# Altium schematic sheet SPI.SchDoc
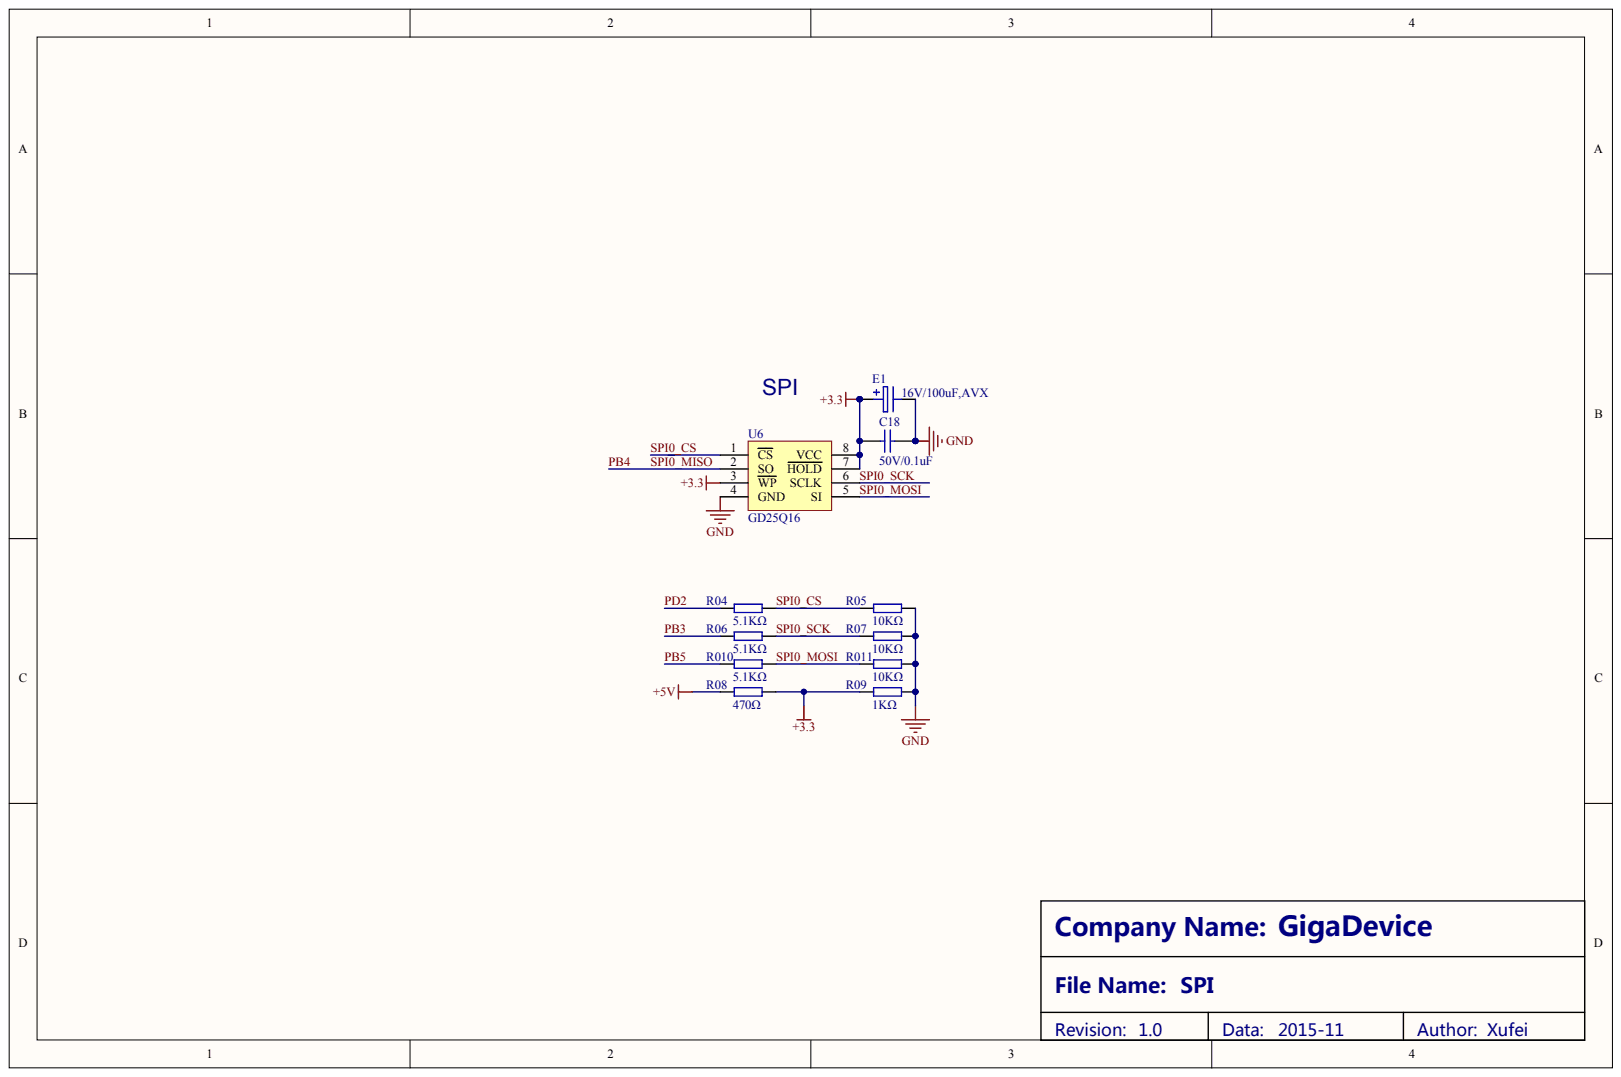
[diagram p0: SPI.SchDoc]

## Parts
C18  50V/0.1uF
E1  16V/100uF,AVX
R04  5.1KΩ
R05  10KΩ
R06  5.1KΩ
R07  10KΩ
R08  470Ω
R09  1KΩ
R010  5.1KΩ
R011  10KΩ
U6  GD25Q16

## Nets
+3.3: C18.1 E1.1 R08.2 R09.1 U6.3(WP) U6.7(HOLD) U6.8(VCC)
+5V: R08.1
GND: C18.2 E1.2 R05.2 R07.2 R09.2 R011.2 U6.4(GND)
PB3: R06.1
PB4: U6.2(SO)
PB5: R010.1
PD2: R04.1
SPI0_CS: R04.2 R05.1 U6.1(CS)
SPI0_MOSI: R010.2 R011.1 U6.5(SI)
SPI0_SCK: R06.2 R07.1 U6.6(SCLK)
